# Altium schematic sheet Transistors.SchDoc(5)
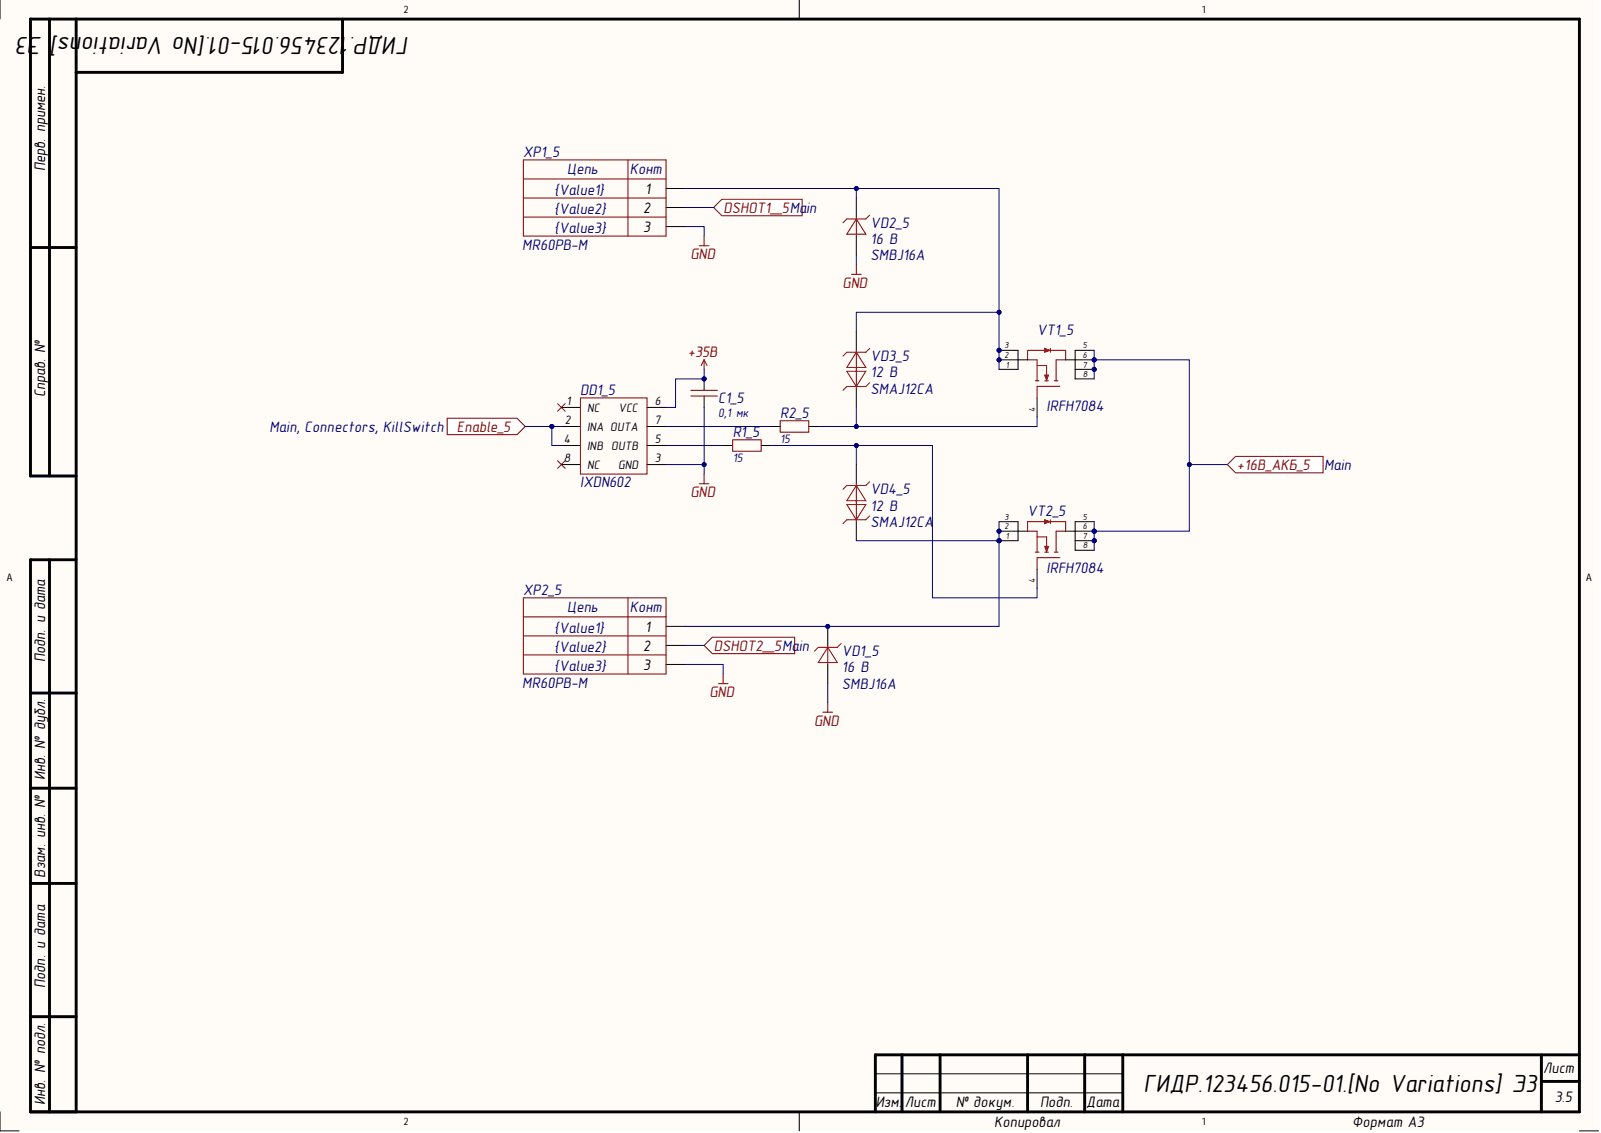
[diagram p0: Transistors.SchDoc]

## Parts
C1_5  value 0,1 мк  Tolerance: 0,1  [0603C]  (hidden comment: Capacitor)
DD1_5  IXDN602  [SOIC-8]
R1_5  value 15  [0603R]  (hidden comment: Resistor)
R2_5  value 15  [0603R]  (hidden comment: Resistor)
VD1_5  SMBJ16A  value 16 В  [SMB]
VD2_5  SMBJ16A  value 16 В  [SMB]
VD3_5  SMAJ12CA  value 12 В  [SMA]
VD4_5  SMAJ12CA  value 12 В  [SMA]
VT1_5  IRFH7084  [PQFN_5x6]
VT2_5  IRFH7084  [PQFN_5x6]
XP1_5  MR60PB-M  Value1: {Value1}  Value2: {Value2}  Value3: {Value3}  [MR60PB-M]
XP2_5  MR60PB-M  Value1: {Value1}  Value2: {Value2}  Value3: {Value3}  [MR60PB-M]

## Ports
+16В_АКБ_5, DSHOT1__5, DSHOT2__5, Enable_5

## Nets
+35В: C1_5.2 DD1_5.6(VCC)
GND: C1_5.1 DD1_5.3(GND) VD1_5.1 VD2_5.1 XP1_5.3 XP2_5.3
NetDD1_5_1: DD1_5.1(NC)
NetDD1_5_2: DD1_5.2(INA) DD1_5.4(INB) ; port Enable_5
NetDD1_5_5: DD1_5.5(OUTB) R1_5.1
NetDD1_5_7: DD1_5.7(OUTA) R2_5.1
NetDD1_5_8: DD1_5.8(NC)
NetR1_5_2: R1_5.2 VD4_5.2 VT2_5.4
NetR2_5_2: R2_5.2 VD3_5.1 VT1_5.4
NetVD1_5_2: VD1_5.2 VD4_5.1 VT2_5.1 VT2_5.2 VT2_5.3 XP2_5.1
NetVD2_5_2: VD2_5.2 VD3_5.2 VT1_5.1 VT1_5.2 VT1_5.3 XP1_5.1
NetVT1_5_5: VT1_5.5 VT1_5.6 VT1_5.7 VT1_5.8 VT2_5.5 VT2_5.6 VT2_5.7 VT2_5.8 ; port +16В_АКБ_5
NetXP1_5_2: XP1_5.2 ; port DSHOT1__5
NetXP2_5_2: XP2_5.2 ; port DSHOT2__5

## Library data of the parts
C1_5 = Capacitor_0,1u_10_50V_X7R_0603C: Конденсатор; Capacity (nF): 100; Database Table Name: Capacitors_ceramic$; Dielectric: X7R; Library Path2: Schematic\Capacitor.SchLib; Voltage (V): 50; Component Kind: Standard; Library Name: Hydrolib.DbLib; Part Number: Capacitor_0,1u_10_50V_X7R_0603C
DD1_5 = IXDN602: Двухканальный драйвер затвора для mosfet и igbt транзисторов; Database Table Name: Chips$; Component Kind: Standard; Library Name: Hydrolib.DbLib; Part Number: IXDN602
R1_5 R2_5 = Resistor_15_1_0603: Резистор номиналом 15 Ом 1%; Database Table Name: Resistors$; Component Kind: Standard; Library Name: Hydrolib.DbLib; Part Number: Resistor_15_1_0603; Tolerance: 1%
VD1_5 VD2_5 = SMBJ16A: Защитный диод на 16 В, рассеиваемая мощность 600 Вт; Database Table Name: TVS$; Power (W): 600; Voltage nominal (V): 16; Component Kind: Standard; Library Name: Hydrolib.DbLib; Part Number: SMBJ16A
VD3_5 VD4_5 = SMAJ12CA: Защитный диод на 12 В, рассеиваемая мощность 400 Вт; Database Table Name: TVS$; Power (W): 400; Voltage nominal (V): 12; Component Kind: Standard; Library Name: Hydrolib.DbLib; Part Number: SMAJ12CA
VT1_5 VT2_5 = IRFH7084: N-канальный MOSFET; Current (A): 100 А; Database Table Name: Transistors$; Voltage (V): 40 В; Component Kind: Standard; Library Name: Hydrolib.DbLib; Part Number: IRFH7084
XP1_5 XP2_5 = MR60PB-M: 60 А (40 продолжительно), 3 контакта, прямой отец; Database Table Name: Connectors$; System: Connector; Component Kind: Standard; Library Name: Hydrolib.DbLib; Part Number: MR60PB-M
